# Altium schematic sheet Driver Transistor Matrix rev4.SchDoc
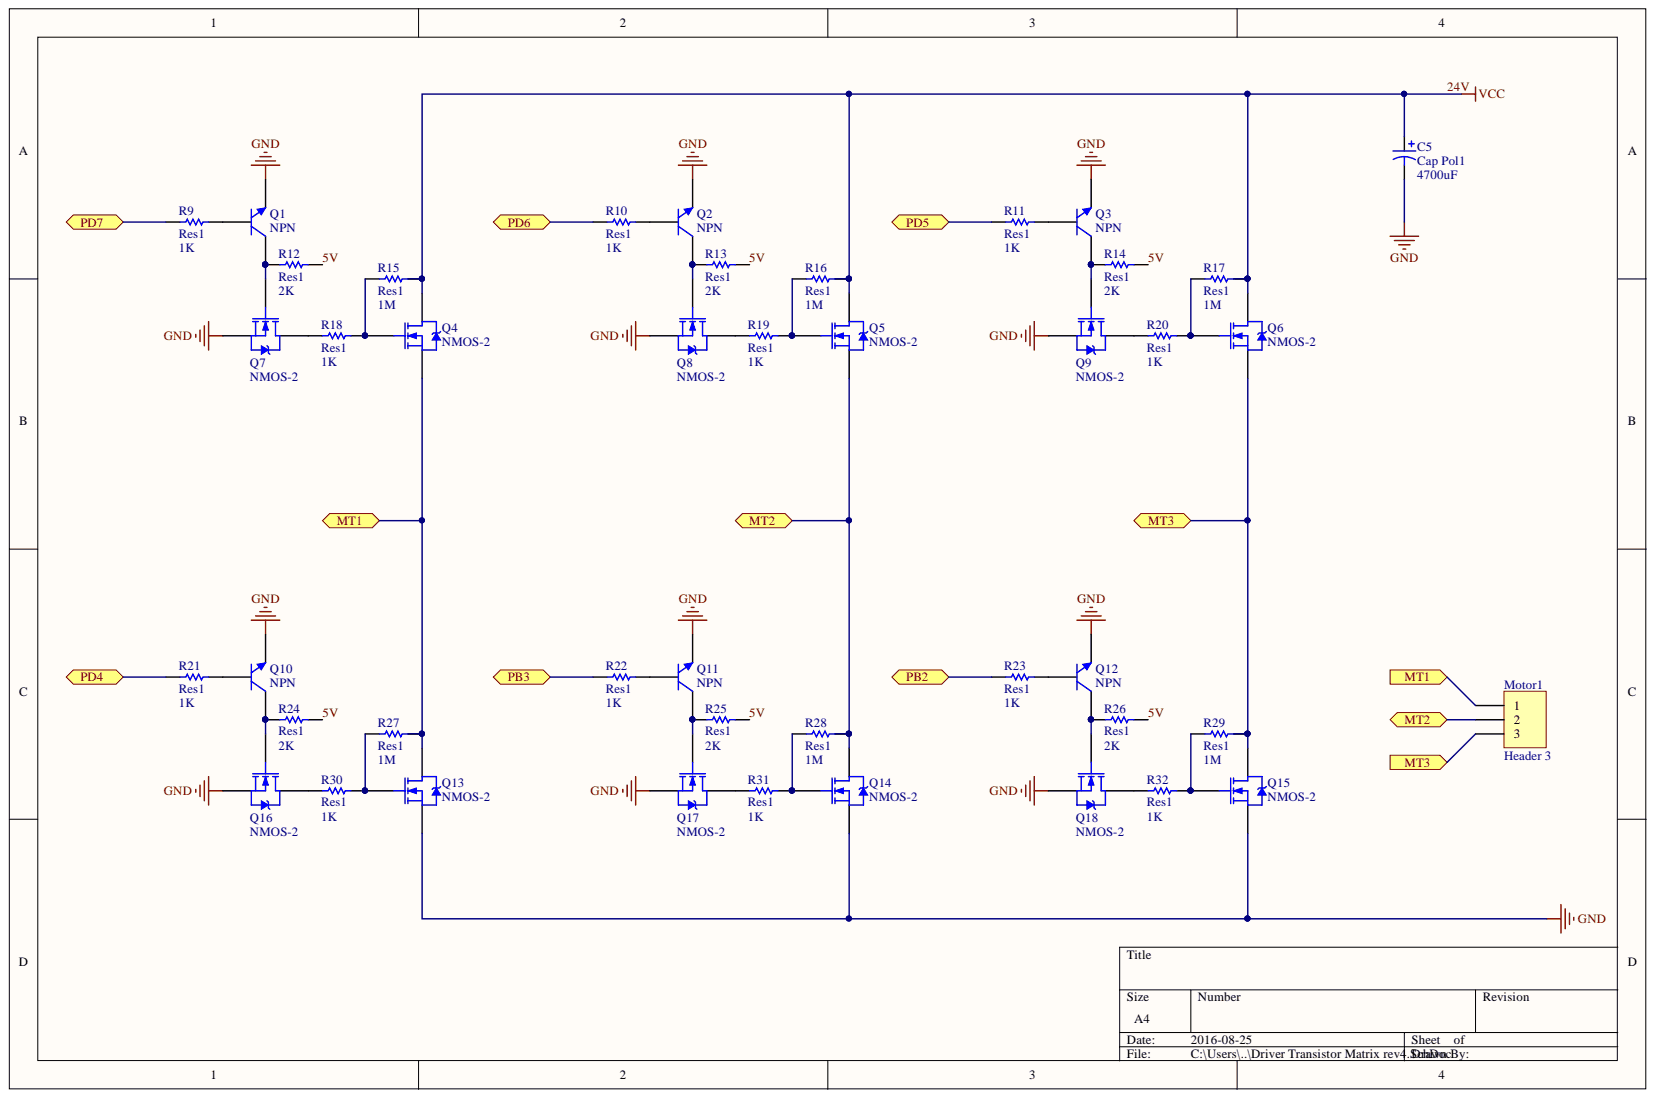
[diagram p0: Driver Transistor Matrix rev4.SchDoc]

## Parts
C5  Cap Pol1  value 4700uF  [RB7.6-15]
Motor1  Header 3  [HDR1X3]
Q1  NPN  [TO-226-AA]
Q2  NPN  [TO-226-AA]
Q3  NPN  [TO-226-AA]
Q4  NMOS-2  [TO-220AB]
Q5  NMOS-2  [TO-220AB]
Q6  NMOS-2  [TO-220AB]
Q7  NMOS-2  [TO-220AB]
Q8  NMOS-2  [TO-220AB]
Q9  NMOS-2  [TO-220AB]
Q10  NPN  [TO-226-AA]
Q11  NPN  [TO-226-AA]
Q12  NPN  [TO-226-AA]
Q13  NMOS-2  [TO-220AB]
Q14  NMOS-2  [TO-220AB]
Q15  NMOS-2  [TO-220AB]
Q16  NMOS-2  [TO-220AB]
Q17  NMOS-2  [TO-220AB]
Q18  NMOS-2  [TO-220AB]
R9  Res1  value 1K  [AXIAL-0.3]
R10  Res1  value 1K  [AXIAL-0.3]
R11  Res1  value 1K  [AXIAL-0.3]
R12  Res1  value 2K  [AXIAL-0.3]
R13  Res1  value 2K  [AXIAL-0.3]
R14  Res1  value 2K  [AXIAL-0.3]
R15  Res1  value 1M  [AXIAL-0.3]
R16  Res1  value 1M  [AXIAL-0.3]
R17  Res1  value 1M  [AXIAL-0.3]
R18  Res1  value 1K  [AXIAL-0.3]
R19  Res1  value 1K  [AXIAL-0.3]
R20  Res1  value 1K  [AXIAL-0.3]
R21  Res1  value 1K  [AXIAL-0.3]
R22  Res1  value 1K  [AXIAL-0.3]
R23  Res1  value 1K  [AXIAL-0.3]
R24  Res1  value 2K  [AXIAL-0.3]
R25  Res1  value 2K  [AXIAL-0.3]
R26  Res1  value 2K  [AXIAL-0.3]
R27  Res1  value 1M  [AXIAL-0.3]
R28  Res1  value 1M  [AXIAL-0.3]
R29  Res1  value 1M  [AXIAL-0.3]
R30  Res1  value 1K  [AXIAL-0.3]
R31  Res1  value 1K  [AXIAL-0.3]
R32  Res1  value 1K  [AXIAL-0.3]

## Ports
MT1, MT2, MT3, PB2, PB3, PD4, PD5, PD6, PD7

## Nets
GND: C5.2 Q1.3 Q2.3 Q3.3 Q7.3 Q8.3 Q9.3 Q10.3 Q11.3 Q12.3 Q13.3 Q14.3 Q15.3 Q16.3 Q17.3 Q18.3
5V: R12.2 R13.2 R14.2 R24.2 R25.2 R26.2
24V: C5.1 Q4.2 Q5.2 Q6.2 R15.2 R16.2 R17.2
MT1: Motor1.1 Q4.3 Q13.2 R27.2 ; port MT1
MT2: Motor1.2 Q5.3 Q14.2 R28.2 ; port MT2
MT3: Motor1.3 Q6.3 Q15.2 R29.2 ; port MT3
PB2: R23.2 ; port PB2
PB3: R22.2 ; port PB3
PD4: R21.2 ; port PD4
PD5: R11.2 ; port PD5
PD6: R10.2 ; port PD6
PD7: R9.2 ; port PD7
NetQ1_1: Q1.1 Q7.1 R12.1
NetQ1_2: Q1.2 R9.1
NetQ2_1: Q2.1 Q8.1 R13.1
NetQ2_2: Q2.2 R10.1
NetQ3_1: Q3.1 Q9.1 R14.1
NetQ3_2: Q3.2 R11.1
NetQ4_1: Q4.1 R15.1 R18.2
NetQ5_1: Q5.1 R16.1 R19.2
NetQ6_1: Q6.1 R17.1 R20.2
NetQ7_2: Q7.2 R18.1
NetQ8_2: Q8.2 R19.1
NetQ9_2: Q9.2 R20.1
NetQ10_1: Q10.1 Q16.1 R24.1
NetQ10_2: Q10.2 R21.1
NetQ11_1: Q11.1 Q17.1 R25.1
NetQ11_2: Q11.2 R22.1
NetQ12_1: Q12.1 Q18.1 R26.1
NetQ12_2: Q12.2 R23.1
NetQ13_1: Q13.1 R27.1 R30.2
NetQ14_1: Q14.1 R28.1 R31.2
NetQ15_1: Q15.1 R29.1 R32.2
NetQ16_2: Q16.2 R30.1
NetQ17_2: Q17.2 R31.1
NetQ18_2: Q18.2 R32.1

## Library data of the parts
C5 = Cap Pol1: Polarized Capacitor (Radial); Component Kind: Standard; LatestRevisionDate: 17-Jul-2002; LatestRevisionNote: Re-released for DXP Platform.; Library Name: Miscellaneous Devices.IntLib; PackageDescription: Polarized Capacitor (Radial); 2 Leads; PackageReference: RB7.6-15; Published: 8-Jun-2000; Signal Integrity: Cap Pol; Simulation: CAP; Publisher: Altium Limited
Motor1 = Header 3: Header, 3-Pin; Component Kind: Standard; LatestRevisionDate: 17-Jul-2002; LatestRevisionNote: Re-released for DXP Platform.; Library Name: Miscellaneous Connectors.IntLib; Signal Integrity: Connector; Publisher: Altium Limited
Q1-Q3 Q10-Q12 = NPN: NPN Bipolar Transistor; Code_JEDEC: TO-226-AA; Component Kind: Standard; LatestRevisionDate: 17-Jul-2002; LatestRevisionNote: Re-released for DXP Platform.; Library Name: Miscellaneous Devices.IntLib; PackageDescription: TO, Flat Index; 3 In-Line, Axial Leads; Body Dia. 4.8mm; Leads 0.55 x 0.51 mm (max); PackageReference: TO-226-AA; Published: 8-Jun-2000; Signal Integrity: NPN; Simulation: NPN; Publisher: Altium Limited
Q4-Q9 Q13-Q18 = NMOS-2: N-Channel Power MOSFET; Note: Pin 2 is electrically connected to pin 4.; Component Kind: Standard; ComponentLink1Description: Manufacturer Link; ComponentLink1URL: http://www.irf.com/; DatasheetVersion: PD-9.814; LatestRevisionDate: 17-Jul-2002; LatestRevisionNote: Re-released for DXP Platform.; Library Name: Miscellaneous Devices.IntLib; PackageDescription: TO, Thru-Hole, Vertical, Heatsink Mounted; 3 In-Line Leads; Pitch 2.54 mm; PackageReference: TO-220AB; PackageVersion: Feb-1997; Published: 8-Jun-2000; Simulation: IRF1010; Publisher: Altium Limited
R9-R11 R21-R23 = Res1: Resistor; Component Kind: Standard; LatestRevisionDate: 17-Jul-2002; LatestRevisionNote: Re-released for DXP Platform.; Library Name: Miscellaneous Devices.IntLib; PackageDescription: Axial Device, Thru-Hole; 2 Leads; 0.3 in Pin Spacing; PackageReference: AXIAL-0.3; Published: 8-Jun-2000; Signal Integrity: Res; Simulation: RESISTOR; Publisher: Altium Limited
R12-R14 R24-R26 = Res1: Resistor; Value: 2K; Component Kind: Standard; LatestRevisionDate: 17-Jul-2002; LatestRevisionNote: Re-released for DXP Platform.; Library Name: Miscellaneous Devices.IntLib; PackageDescription: Axial Device, Thru-Hole; 2 Leads; 0.3 in Pin Spacing; PackageReference: AXIAL-0.3; Published: 8-Jun-2000; Signal Integrity: Res; Simulation: RESISTOR; Publisher: Altium Limited
R15-R17 R27-R29 = Res1: Resistor; Value: 1M; Component Kind: Standard; LatestRevisionDate: 17-Jul-2002; LatestRevisionNote: Re-released for DXP Platform.; Library Name: Miscellaneous Devices.IntLib; PackageDescription: Axial Device, Thru-Hole; 2 Leads; 0.3 in Pin Spacing; PackageReference: AXIAL-0.3; Published: 8-Jun-2000; Signal Integrity: Res; Simulation: RESISTOR; Publisher: Altium Limited
R18-R20 R30-R32 = Res1: Resistor; Value: 1K; Component Kind: Standard; LatestRevisionDate: 17-Jul-2002; LatestRevisionNote: Re-released for DXP Platform.; Library Name: Miscellaneous Devices.IntLib; PackageDescription: Axial Device, Thru-Hole; 2 Leads; 0.3 in Pin Spacing; PackageReference: AXIAL-0.3; Published: 8-Jun-2000; Signal Integrity: Res; Simulation: RESISTOR; Publisher: Altium Limited
